# Altium schematic sheet Thermistor_Interface.SchDoc
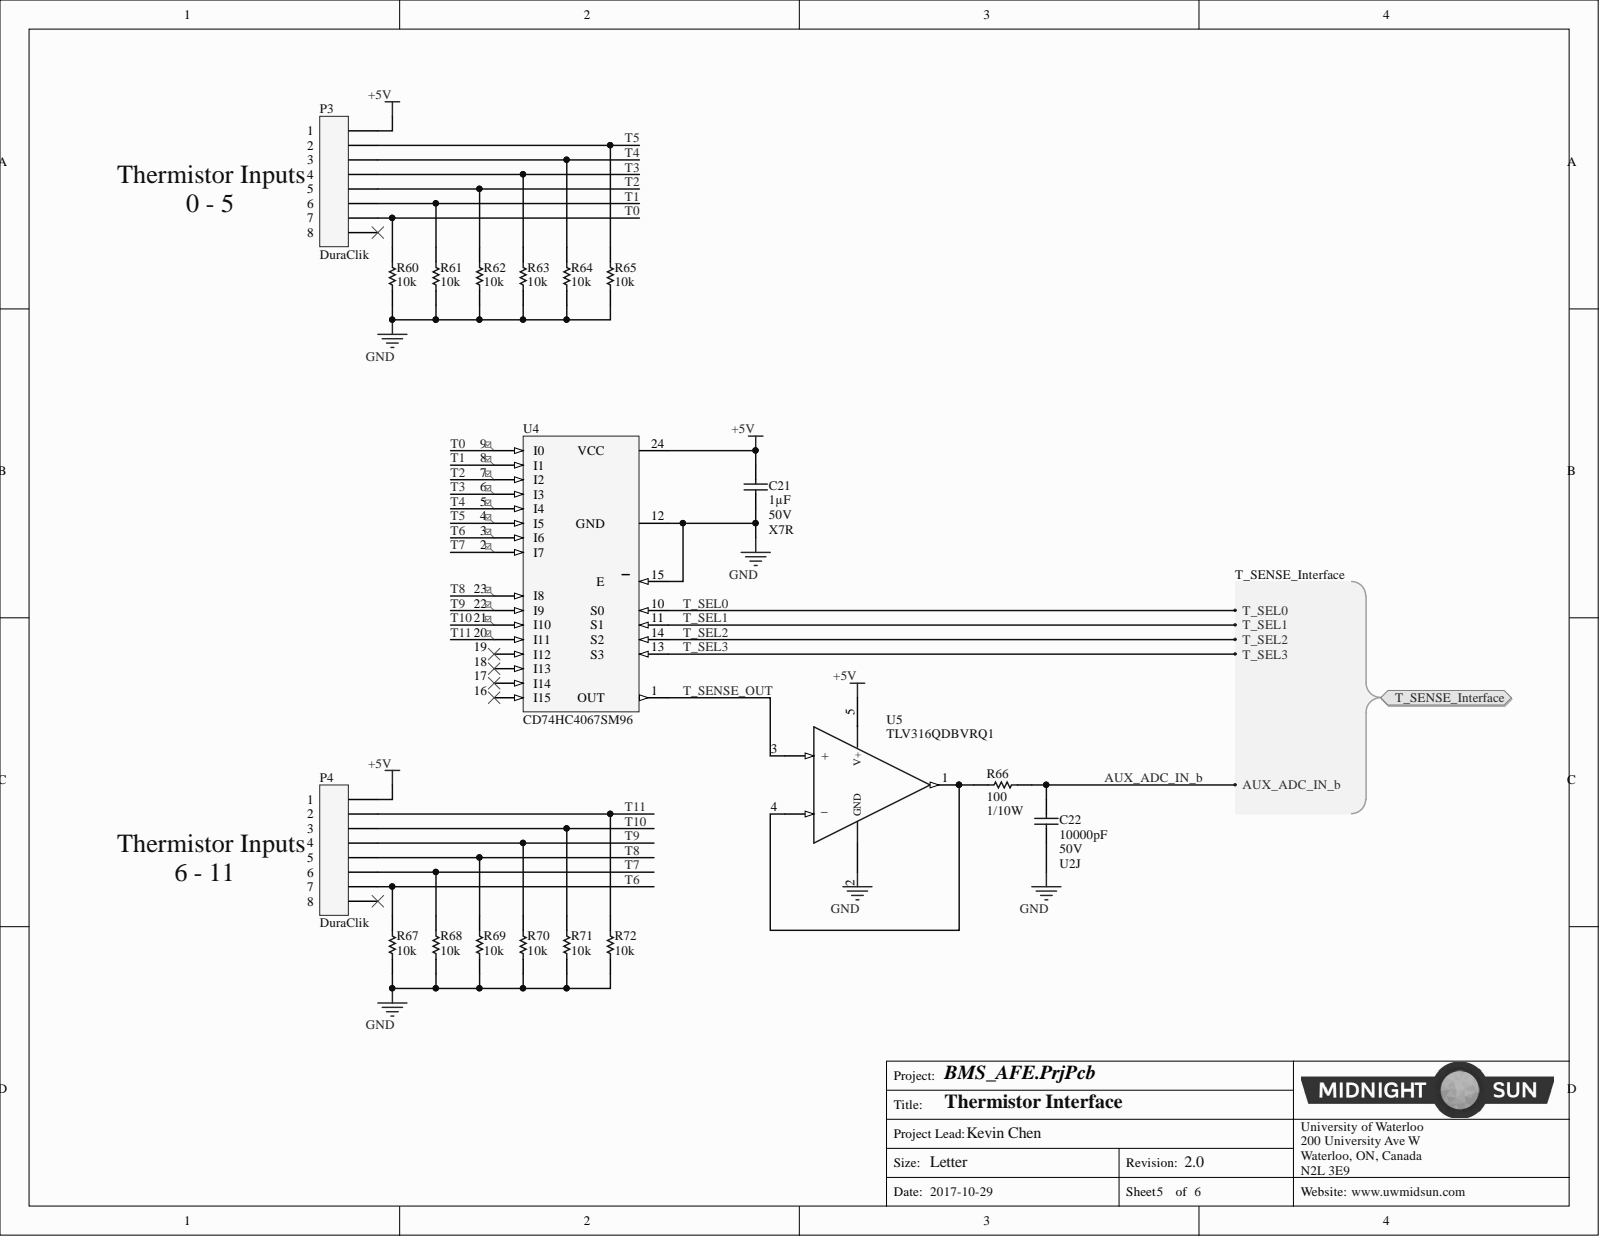
[diagram p0: Thermistor_Interface.SchDoc]

## Parts
C21  Capacitance: 1µF  Temperature Coefficient: X7R  Voltage: 50V  [CAP, 0603]  (hidden comment: CAP CER 1UF 50V 10% X7R 0603)
C22  Capacitance: 10000pF  Temperature Coefficient: U2J  Voltage: 50V  [CAP, 0603]  (hidden comment: CAP CER 10nF 50V 5% X7R 0603)
P3  Series: DuraClik  [CONN, 8POS Dura-Clik]  (hidden comment: CONN 8POS DURA-CLIK 0.079")
P4  Series: DuraClik  [CONN, 8POS Dura-Clik]  (hidden comment: CONN 8POS DURA-CLIK 0.079")
R60  Resistance: 10k  [RES, 0603]  (hidden comment: RES 10K OHM 1% 1/10W 0603)
R61  Resistance: 10k  [RES, 0603]  (hidden comment: RES 10K OHM 1% 1/10W 0603)
R62  Resistance: 10k  [RES, 0603]  (hidden comment: RES 10K OHM 1% 1/10W 0603)
R63  Resistance: 10k  [RES, 0603]  (hidden comment: RES 10K OHM 1% 1/10W 0603)
R64  Resistance: 10k  [RES, 0603]  (hidden comment: RES 10K OHM 1% 1/10W 0603)
R65  Resistance: 10k  [RES, 0603]  (hidden comment: RES 10K OHM 1% 1/10W 0603)
R66  RES 100 OHM 1% 1/10W 0603  [RES, 0603]  (value not drawn)
R67  Resistance: 10k  [RES, 0603]  (hidden comment: RES 10K OHM 1% 1/10W 0603)
R68  Resistance: 10k  [RES, 0603]  (hidden comment: RES 10K OHM 1% 1/10W 0603)
R69  Resistance: 10k  [RES, 0603]  (hidden comment: RES 10K OHM 1% 1/10W 0603)
R70  Resistance: 10k  [RES, 0603]  (hidden comment: RES 10K OHM 1% 1/10W 0603)
R71  Resistance: 10k  [RES, 0603]  (hidden comment: RES 10K OHM 1% 1/10W 0603)
R72  Resistance: 10k  [RES, 0603]  (hidden comment: RES 10K OHM 1% 1/10W 0603)
U4  Manufacturer Part Number 1: CD74HC4067SM96  [SOP127P1030X265-24N]  (hidden comment: IC MUX/DEMUX 1X16 24SSOP)
U5  Manufacturer Part Number 1: TLV316QDBVRQ1  [IC OP AMP GP RR 10MHZ SOT-23-5]  (hidden comment: IC OP AMP GEN PURPOSE RR 10MHZ SOT-23-5)

## Ports
T_SENSE_INTERFACE, T_SENSE_INTERFACE.AUX_ADC_IN_B, T_SENSE_INTERFACE.T_SEL0, T_SENSE_INTERFACE.T_SEL1, T_SENSE_INTERFACE.T_SEL2, T_SENSE_INTERFACE.T_SEL3

## Nets
+5V: C21.1 P3.1 P4.1 U4.24 U5.5
GND: C21.2 C22.2 R60.1 R61.1 R62.2 R63.2 R64.2 R65.2 R67.1 R68.1 R69.1 R70.1 R71.1 R72.1 U4.12 U4.15 U5.2
AUX_ADC_IN_B: C22.1 R66.2 ; port T_SENSE_INTERFACE
T0: P3.7 R60.2 U4.9(I0)
T1: P3.6 R61.2 U4.8(I1)
T2: P3.5 R62.1 U4.7(I2)
T3: P3.4 R63.1 U4.6(I3)
T4: P3.3 R64.1 U4.5(I4)
T5: P3.2 R65.1 U4.4(I5)
T6: P4.7 R67.2 U4.3(I6)
T7: P4.6 R68.2 U4.2(I7)
T8: P4.5 R69.2 U4.23(I8)
T9: P4.4 R70.2 U4.22(I9)
T10: P4.3 R71.2 U4.21(I10)
T11: P4.2 R72.2 U4.20(I11)
T_SEL0: U4.10 ; port T_SENSE_INTERFACE
T_SEL1: U4.11 ; port T_SENSE_INTERFACE
T_SEL2: U4.14 ; port T_SENSE_INTERFACE
T_SEL3: U4.13 ; port T_SENSE_INTERFACE
T_SENSE_OUT: U4.1 U5.3(+)
NetR66_1: R66.1 U5.1 U5.4

Not connected: P3 8; P4 8; U4 16(I15) 17(I14) 18(I13) 19(I12)

## Library data of the parts
C21 = CAP CER 1UF 50V 10% X7R 0603: 1µF ±10% 50V Ceramic Capacitor X7R 0603 (1608 Metric); Manufacturer 1: Taiyo Yuden; Manufacturer Part Number 1: UMK107AB7105KA-T; Component Kind: Standard; ComponentLink1Description: http://www.yuden.co.jp/productdata/catalog/en/mlcc_all_e.pdf; ComponentLink1URL: http://www.yuden.co.jp/productdata/catalog/en/mlcc_all_e.pdf; Height - Seated (Max): -; Lead Spacing: -; Lead Style: -; Mounting Type: Surface Mount, MLCC; Operating Temperature: -55°C ~ 125°C; Package / Case: 0603 (1608 Metric); Packaging: Cut Tape (CT); Part Status: Active; Ratings: -; Size / Dimension: 0.063" L x 0.031" W (1.60mm x 0.80mm); Supplier 1: Digi-Key; Supplier Part Number 1: 587-3247-1-ND; Thickness (Max): 0.037" (0.95mm); Tolerance: ±10%; Library Name: Schematic Diagrams.SchLib
C22 = CAP CER 10nF 50V 5% X7R 0603: 10000pF ±5% 50V Ceramic Capacitor U2J 0603 (1608 Metric); Manufacturer 1: KEMET; Manufacturer Part Number 1: C0603C103J5JAC7867; Component Kind: Standard; ComponentLink1Description: http://www.kemet.com/docfinder?Partnumber=C0603C103J5JAC7867; ComponentLink1URL: http://www.kemet.com/docfinder?Partnumber=C0603C103J5JAC7867; Failure Rate: -; Height - Seated (Max): -; Lead Spacing: -; Lead Style: -; Mounting Type: Surface Mount, MLCC; Operating Temperature: -55°C ~ 125°C; Package / Case: 0603 (1608 Metric); Packaging: Cut Tape (CT); Part Status: Active; Ratings: -; Size / Dimension: 0.063" L x 0.032" W (1.60mm x 0.80mm); Supplier 1: Digi-Key; Supplier Part Number 1: 399-13384-1-ND; Thickness (Max): 0.034" (0.87mm); Tolerance: ±5%; Library Name: Schematic Diagrams.SchLib
P3 P4 = CONN 8POS DURA-CLIK 0.079": 8 Positions Header Connector 0.079" (2.00mm) Surface Mount Tin; Manufacturer 1: Molex, LLC; Manufacturer Part Number 1: 5600200820; Mated Stacking Heights: 9.3mm; Number of Positions: 8; Number of Positions Loaded: All; Shrouding: Shrouded - 4 Wall; Style: Board to Cable/Wire; Component Kind: Standard; ComponentLink1Description: http://www.molex.com/pdm_docs/sd/5600200820_sd.pdf; ComponentLink1URL: http://www.molex.com/pdm_docs/sd/5600200820_sd.pdf; Connector Type: Header; Contact Finish - Mating: Tin; Contact Finish - Post: Tin; Contact Finish Thickness - Mating: -; Contact Finish Thickness - Post: -; Contact Length - Mating: -; Contact Length - Post: -; Contact Material: Copper Alloy; Contact Shape: Square; Contact Type: Male Pin; Current Rating: -; Fastening Type: Latch Lock; Ingress Protection: -; Insulation Color: Natural; Insulation Height: 0.315" (8.00mm); Insulation Material: Polyamide (PA), Nylon; Material Flammability Rating: -; Mounting Type: Surface Mount; Number of Rows: 1; Operating Temperature: -; Overall Contact Length: -; Packaging: Cut Tape (CT); Part Status: Active; Pitch - Mating: 0.079" (2.00mm); Row Spacing - Mating: -; Supplier 1: Digi-Key; Supplier Part Number 1: WM10868CT-ND; Termination: Solder; Voltage: -; Library Name: Schematic Diagrams.SchLib
R60-R65 R67-R72 = RES 10K OHM 1% 1/10W 0603: RES SMD 10K OHM 1% 1/10W 0603; Manufacturer 1: Yageo; Manufacturer Part Number 1: RC0603FR-0710KL; Temperature Coefficient: ±100ppm/°C; Component Kind: Standard; ComponentLink1Description: http://www.yageo.com/documents/recent/PYu-RC_Group_51_RoHS_L_7.pdf; ComponentLink1URL: http://www.yageo.com/documents/recent/PYu-RC_Group_51_RoHS_L_7.pdf; Composition: Thick Film; Failure Rate: -; Height - Seated (Max): 0.022" (0.55mm); Operating Temperature: -55°C ~ 155°C; Package / Case: 0603 (1608 Metric); Packaging: Cut Tape (CT); Part Status: Active; Power: 1/10W; Size / Dimension: 0.063" L x 0.031" W (1.60mm x 0.80mm); Supplier 1: Digi-Key; Supplier Device Package: 0603; Supplier Part Number 1: 311-10.0KHRCT-ND; Tolerance: ±1%; Library Name: Schematic Diagrams.SchLib
R66 = RES 100 OHM 1% 1/10W 0603: 100 Ohm ±1% 0.1W, 1/10W Chip Resistor 0603 (1608 Metric) Moisture Resistant Thick Film; Manufacturer 1: Yageo; Manufacturer Part Number 1: RC0603FR-07100RL; Resistance: 100; Temperature Coefficient: ±100ppm/°C; Component Kind: Standard; ComponentLink1Description: http://www.yageo.com/documents/recent/PYu-RC_Group_51_RoHS_L_7.pdf; ComponentLink1URL: http://www.yageo.com/documents/recent/PYu-RC_Group_51_RoHS_L_7.pdf; Composition: Thick Film; Failure Rate: -; Height - Seated (Max): 0.022" (0.55mm); Operating Temperature: -55°C ~ 155°C; Package / Case: 0603 (1608 Metric); Packaging: Cut Tape (CT); Part Status: Active; Power: 1/10W; Size / Dimension: 0.063" L x 0.031" W (1.60mm x 0.80mm); Supplier 1: Digi-Key; Supplier Device Package: 0603; Supplier Part Number 1: 311-100HRCT-ND; Tolerance: ±1%; Library Name: Schematic Diagrams.SchLib
U4 = IC MUX/DEMUX 1X16 24SSOP: 1 Circuit IC Switch 16:1 160 Ohm 24-SSOP; Manufacturer 1: Texas Instruments; -3db Bandwidth: 89MHz; Channel-to-Channel Matching (&Delta;Ron): 8.5 Ohm; Channel Capacitance (CS(off), CD(off)): 5pF, 50pF; Current - Leakage (IS(off)) (Max): 800nA; Number of Circuits: 1; On-State Resistance (Max): 160 Ohm; Switch Time (Ton, Toff) (Max): 51ns, 49ns; Voltage - Supply, Single (V+): 2 V ~ 6 V; Charge Injection: -; Component Kind: Standard; ComponentLink1Description: http://www.ti.com/lit/ds/symlink/cd74hc4067.pdf; ComponentLink1URL: http://www.ti.com/lit/ds/symlink/cd74hc4067.pdf; Crosstalk: -; Multiplexer/Demultiplexer Circuit: 16:1; Operating Temperature: -55°C ~ 125°C (TA); Package / Case: 24-SSOP (0.209", 5.30mm Width); Packaging: Cut Tape (CT); Part Status: Active; Supplier 1: Digi-Key; Supplier Device Package: 24-SSOP; Supplier Part Number 1: 296-9226-1-ND; Switch Circuit: -; Voltage - Supply, Dual (V±): -; Library Name: Schematic Diagrams.SchLib
U5 = IC OP AMP GEN PURPOSE RR 10MHZ SOT-23-5: General Purpose Amplifier 1 Circuit Rail-to-Rail SOT-23-5; Manufacturer 1: Texas Instruments; Current - Output / Channel: 50mA; Current - Supply: 400µA; Description 1: IC OP AMP GP RR 10MHZ 5-SOT-23; Number of Circuits: 1; Slew Rate: 6 V/µs; Voltage - Supply, Single/Dual (±): 1.8 V ~ 5.5 V, ±0.9 V ~ 2.75 V; -3db Bandwidth: -; Amplifier Type: General Purpose; Category: Integrated Circuits (ICs); Component Kind: Standard; ComponentLink1Description: http://www.ti.com/lit/ds/symlink/tlv316-q1.pdf; ComponentLink1URL: http://www.ti.com/lit/ds/symlink/tlv316-q1.pdf; Mounting Type: Surface Mount; Operating Temperature: -40°C ~ 125°C; Output Type: Rail-to-Rail; Package / Case: SC-74A, SOT-753; Packaging: Cut Tape (CT); Part Status: Active; Pricing 1: 1=1.33, 10=1.185, 100=0.9234, 500=0.76276, 1000=0.60218 (CAD); RoHS: RoHS Compliant; Stock 1: 1747; Supplier 1: Digi-Key; Supplier Device Package: SOT-23-5; Supplier Part Number 1: 296-45323-1-ND; Library Name: Schematic Diagrams.SchLib
